# Altium schematic sheet Interface.SchDoc(Interface)
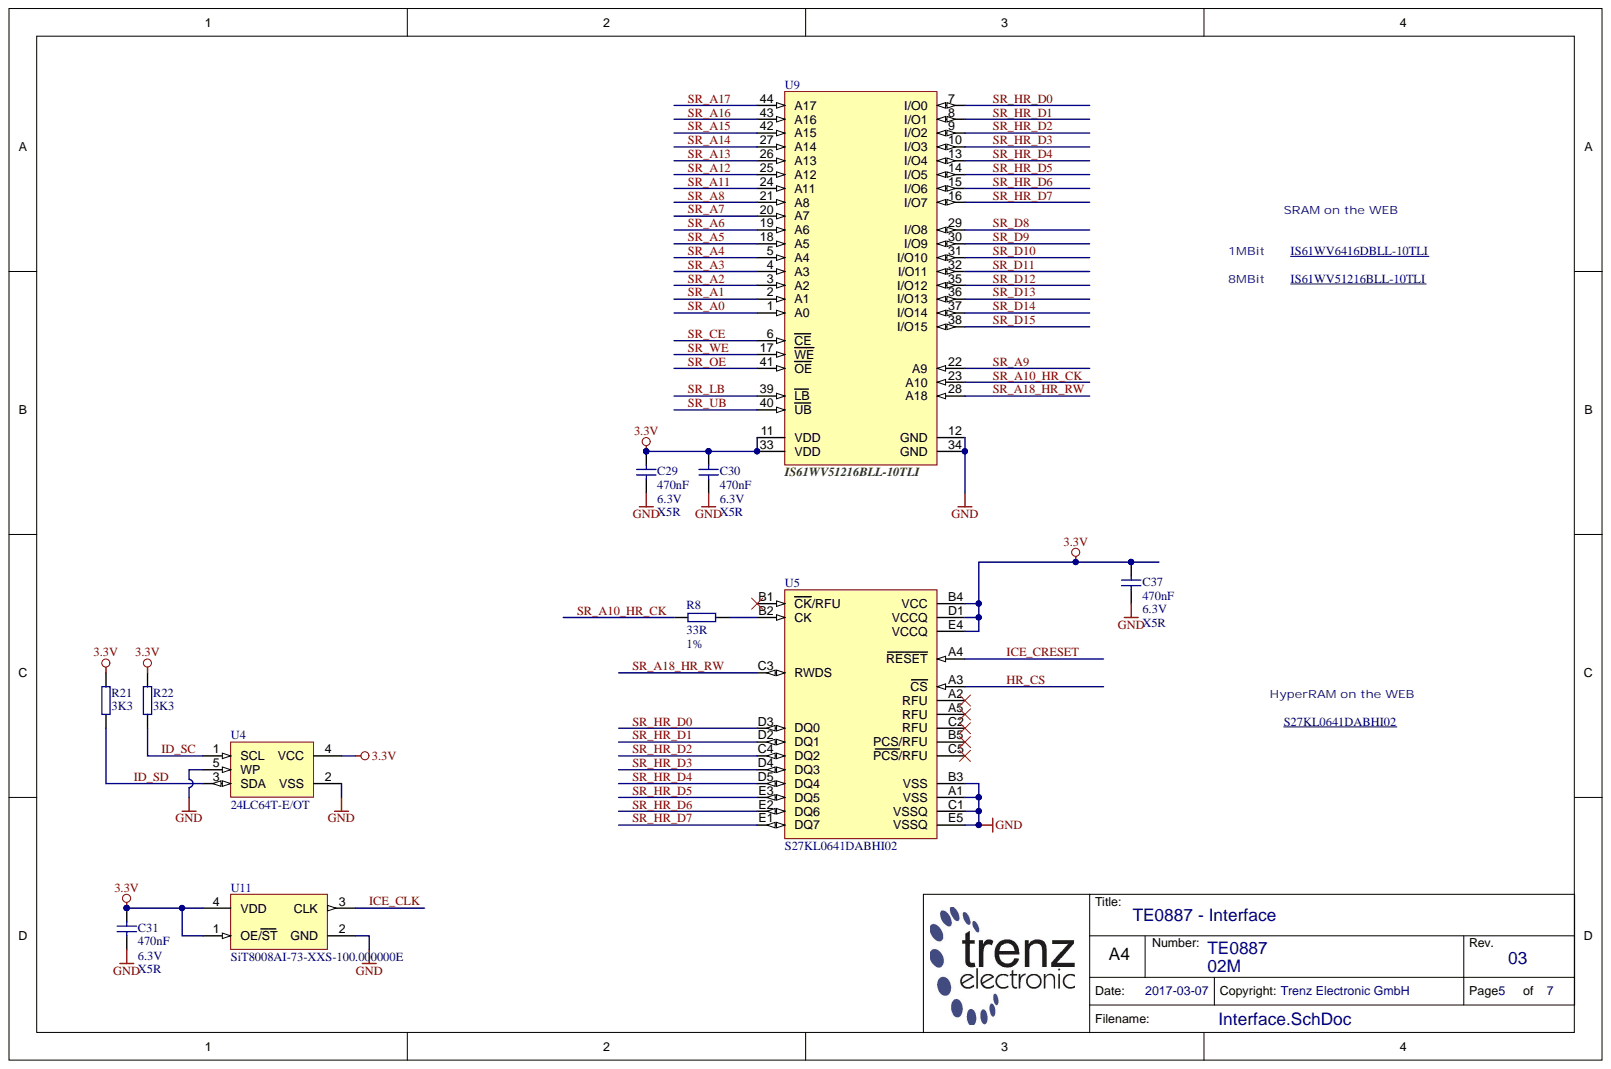
[diagram p0: Interface.SchDoc]

## Parts
C29  470nF  Technology: X5R  Voltage: 6.3V  [CAPC0603X33N]
C30  470nF  Technology: X5R  Voltage: 6.3V  [CAPC0603X33N]
C31  470nF  Technology: X5R  Voltage: 6.3V  [CAPC0603X33N]
C37  470nF  Technology: X5R  Voltage: 6.3V  [CAPC0603X33N]
R8  33R  Tolerance: 1%  [RESC1005X40N]
R21  3K3  [RESC1005X40N]
R22  3K3  [RESC1005X40N]
U4  24LC64T-E/OT  [SOT95P280X145-5N]
U5  S27KL0641DABHI02  [BGA25C100P5X5_800X600X120N]
U9  IS61WV51216BLL-10TLI  [SOP80P1176X120-44L29]
U11  SiT8008AI-73-XXS-100.000000E  Database Table Name: CLK  [2016]

## Nets
3.3V: C29.1 C30.1 C31.1 C37.1 R21.2 R22.2 U4.4(VCC) U5.B4(VCC) U5.D1(VCCQ) U5.E4(VCCQ) U9.11 U9.33 U11.1(OE/ST) U11.4(VDD)
GND: C29.2 C30.2 C31.2 C37.2 U4.2(VSS) U4.5(WP) U5.A1(VSS) U5.B3(VSS) U5.C1(VSSQ) U5.E5(VSSQ) U9.12 U9.34 U11.2(GND)
HR_CS: U5.A3(CS)
ICE_CLK: U11.3(CLK)
ICE_CRESET: U5.A4(RESET)
ID_SC: R22.1 U4.1(SCL)
ID_SD: R21.1 U4.3(SDA)
SR_A0: U9.1
SR_A1: U9.2
SR_A2: U9.3
SR_A3: U9.4
SR_A4: U9.5
SR_A5: U9.18
SR_A6: U9.19
SR_A7: U9.20
SR_A8: U9.21
SR_A9: U9.22
SR_A10_HR_CK: R8.1 U9.23
SR_A11: U9.24
SR_A12: U9.25
SR_A13: U9.26
SR_A14: U9.27
SR_A15: U9.42
SR_A16: U9.43
SR_A17: U9.44
SR_A18_HR_RW: U5.C3(RWDS) U9.28
SR_CE: U9.6
SR_D8: U9.29
SR_D9: U9.30
SR_D10: U9.31
SR_D11: U9.32
SR_D12: U9.35
SR_D13: U9.36
SR_D14: U9.37
SR_D15: U9.38
SR_HR_D0: U5.D3(DQ0) U9.7
SR_HR_D1: U5.D2(DQ1) U9.8
SR_HR_D2: U5.C4(DQ2) U9.9
SR_HR_D3: U5.D4(DQ3) U9.10
SR_HR_D4: U5.D5(DQ4) U9.13
SR_HR_D5: U5.E3(DQ5) U9.14
SR_HR_D6: U5.E2(DQ6) U9.15
SR_HR_D7: U5.E1(DQ7) U9.16
SR_LB: U9.39
SR_OE: U9.41
SR_UB: U9.40
SR_WE: U9.17
NetR8_2: R8.2 U5.B2(CK)

Not connected: U5 A2(RFU) A5(RFU) B1(CK/RFU) B5(PCS/RFU) C2(RFU) C5(PCS/RFU)

## Library data of the parts
C29-C31 C37 = 24921: KON 470nF X5R 6.3V SMD 20%; Database Table Name: C_0603_0201; Mixed: SMT; Preferred: Yes; Value: 470n; AN: 24921; Case: 0603 (0201); Component Kind: Standard; Library Name: TE.DbLib; Tolerance: 20%
R8 = 22770: WID SMD 33R 1% 0,063W; Database Table Name: R_1005_0402; Mixed: SMT; Preferred: Yes; Value: 33; AN: 22770; Case: 1005 (0402); Component Kind: Standard; Library Name: TE.DbLib; Technology: TK100
R21 R22 = 22763: WID SMD 3K3 1% 0,063W; Database Table Name: R_1005_0402; Mixed: SMT; Preferred: Yes; Value: 3.3K; AN: 22763; Case: 1005 (0402); Component Kind: Standard; Library Name: TE.DbLib; Technology: TK100; Tolerance: 1%
U4 = 26684: Database Table Name: A_EEPROM; Mixed: SMT; Trenz-Stock: Yes; AN: 26684; Case: SOT23-5; Component Kind: Standard; Description: 24LC64T-E/OT; H-ART: 24LC64T-E/OT; Library Name: TE.DbLib; HelpURL: B:\ad\adlib\datasheet\24LC02BHT
U5 = 27900: Database Table Name: FLASH; Mixed: SMT; AN: 27900; Case: TFBGA25; Component Kind: Standard; Description: S27KL0641DABHI02; H-ART: S27KL0641DABHI02; Library Name: TE.DbLib; HelpURL: B:\ad\adlib\datasheet\S27KS_KL-S
U9 = 27435: Database Table Name: SRAM; Mixed: SMT; AN: 27435; Case: TSOP2-44; Component Kind: Standard; Description: IS61WV51216BLL-10TLI; H-ART: IS61WV51216BLL-10TLI; Library Name: TE.DbLib; HelpURL: B:\AD\adlib\datasheet\IS61WV51216xLL
U11 = 25961: SiT8008AI-73-XXS-100.000000E MEMS Oszillator 100MHz; Mixed: SMT; Preferred: Yes; Value: 100M; AN: 25961; Case: 2.0  x 1.6mm; Component Kind: Standard; H-ART: SiT8008AI-73-XXS-100.000000E; Library Name: TE.DbLib; Trenz-Stock: Yes; HelpURL: B:\AD\adlib\datasheet\SiT8008
